# Altium schematic sheet Microcontroleur.SchDoc
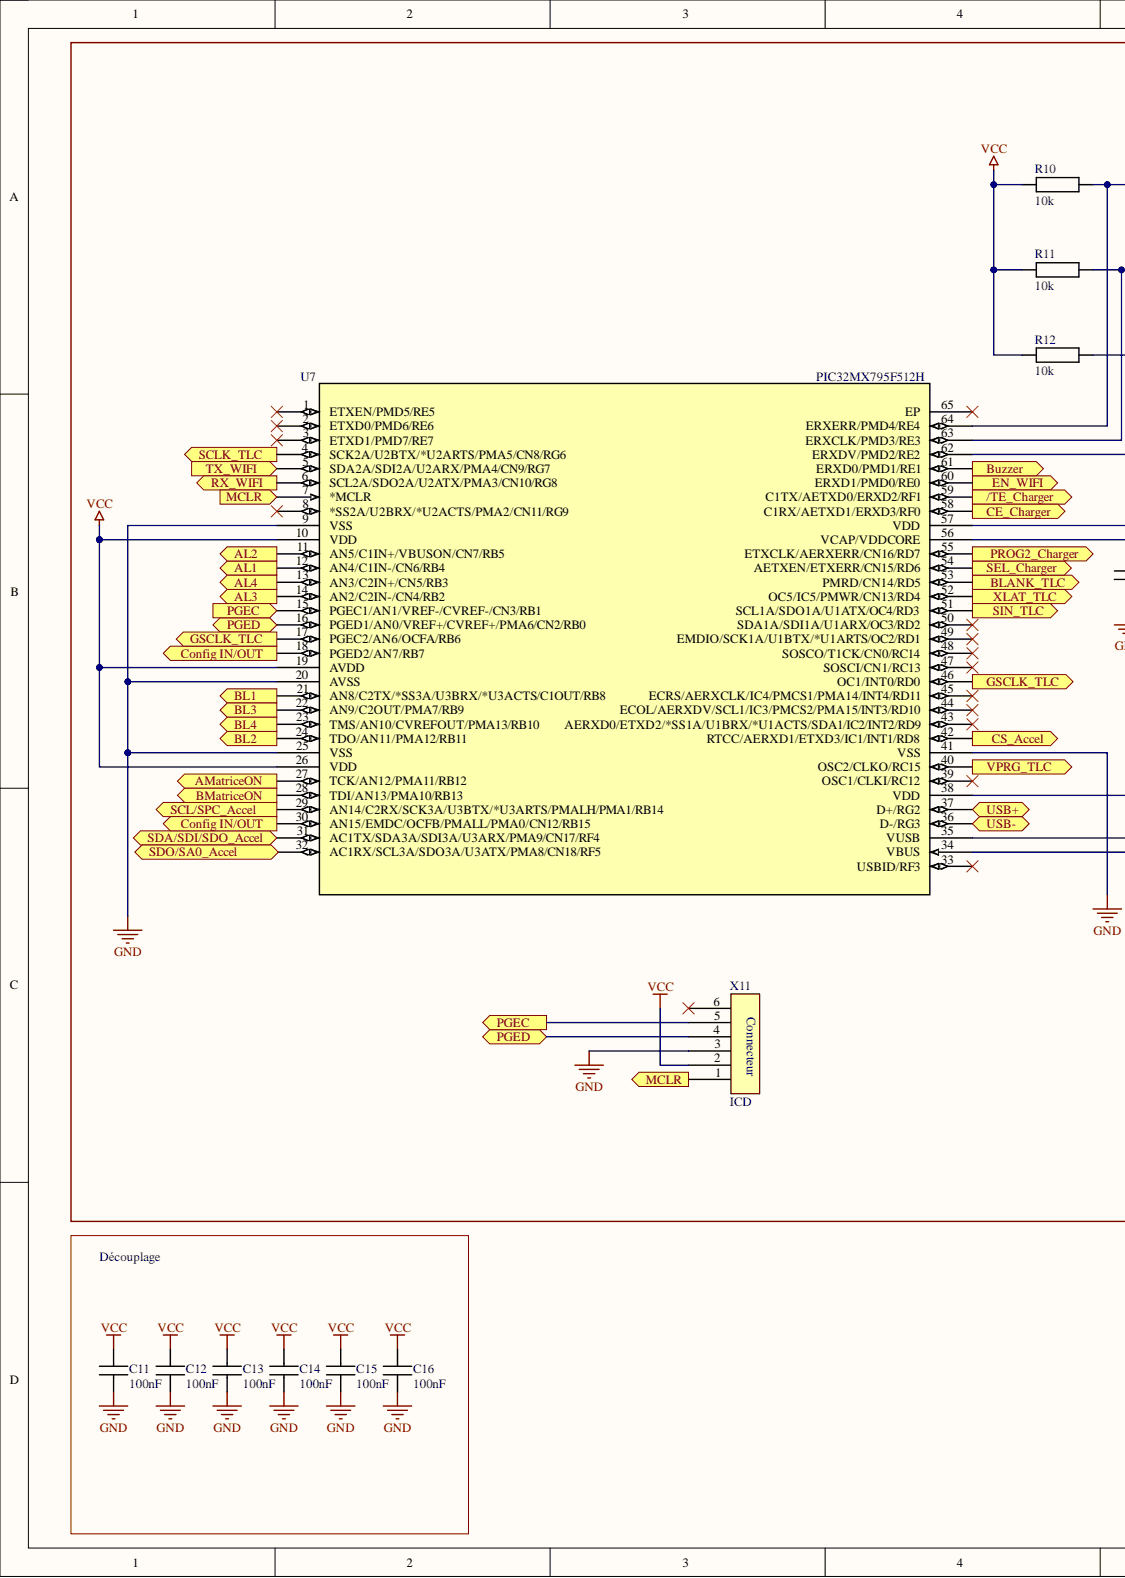
[diagram p0: Microcontroleur.SchDoc, left]
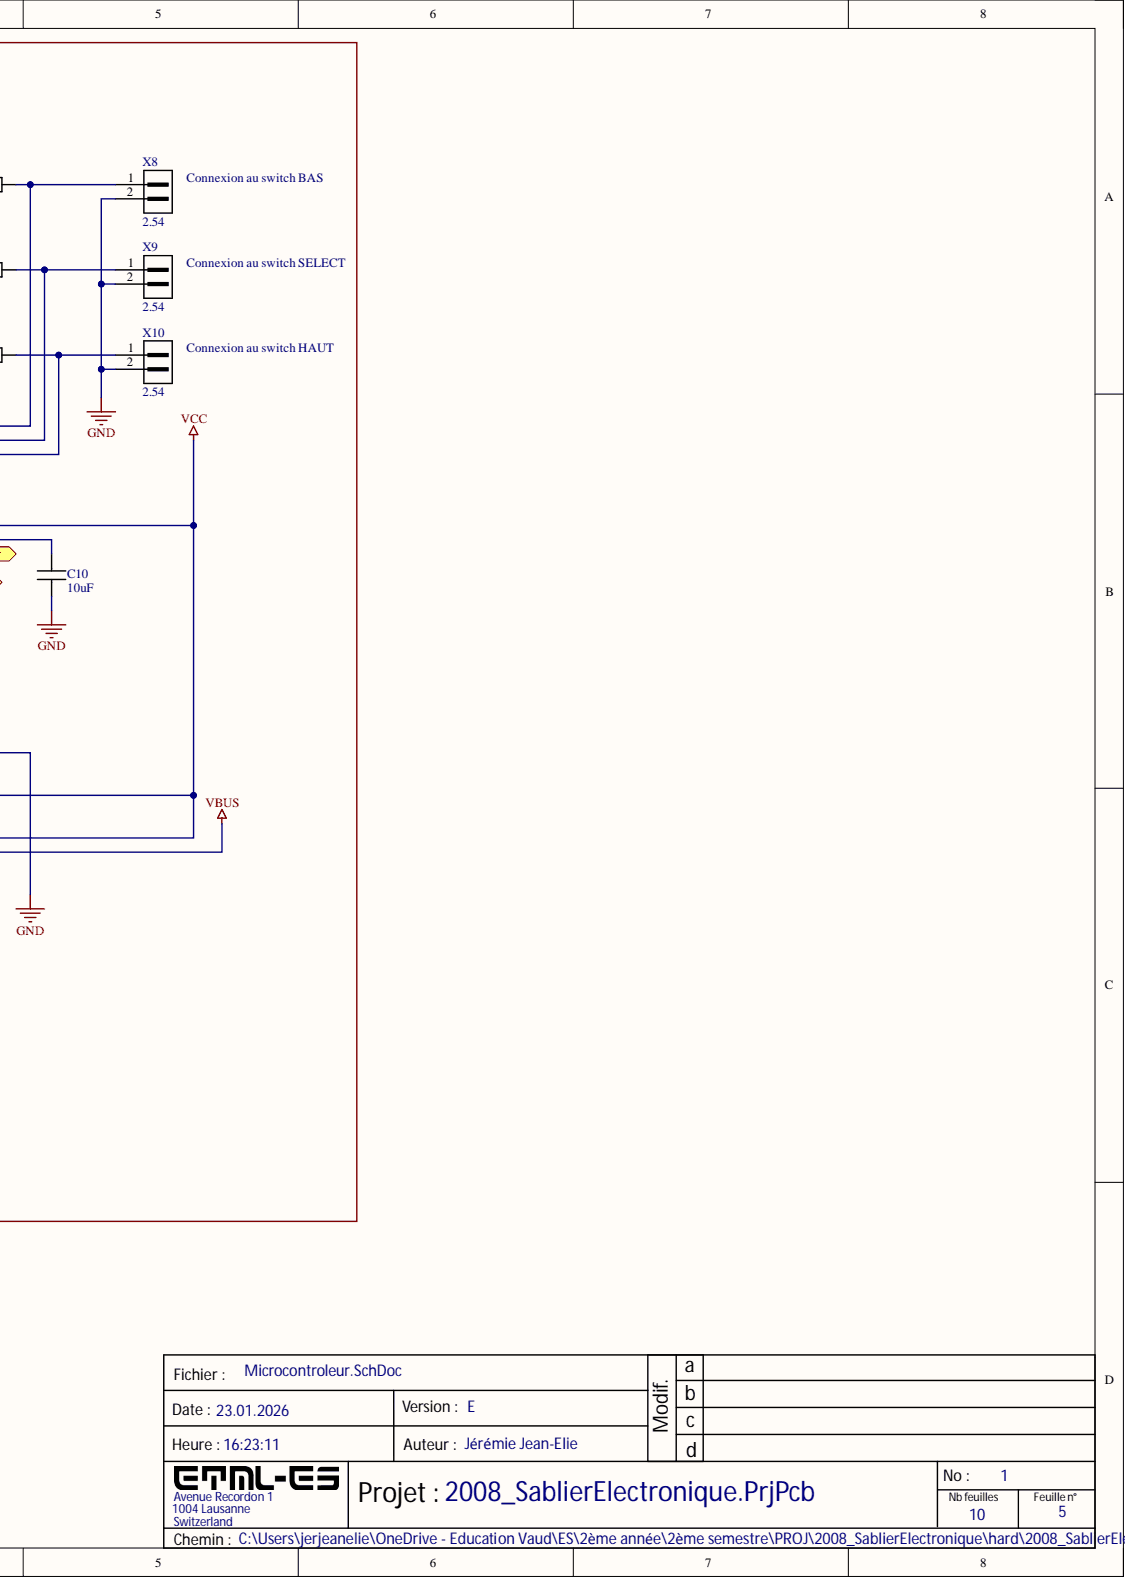
[diagram p1: Microcontroleur.SchDoc, right]

## Parts
C10  10uF  [CAPC1608X08M]
C11  100nF  [CAPC1608X08M]
C12  100nF  [CAPC1608X08M]
C13  100nF  [CAPC1608X08M]
C14  100nF  [CAPC1608X08M]
C15  100nF  [CAPC1608X08M]
C16  100nF  [CAPC1608X08M]
R10  10k  [RESC1608X06M]
R11  10k  [RESC1608X06M]
R12  10k  [RESC1608X06M]
U7  PIC32MX795F512H
X8  2.54  [PIN-1x02-2.54]
X9  2.54  [PIN-1x02-2.54]
X10  2.54  [PIN-1x02-2.54]
X11  ICD  [CON6]

## Ports
/TE_Charger, AL1, AL2, AL3, AL4, AMatriceON, BL1, BL2, BL3, BL4, BLANK_TLC, BMatriceON, Buzzer, CE_Charger, Config IN/OUT, CS_Accel, EN_WIFI, GSCLK_TLC, MCLR, PGEC, PGED, PROG2_Charger, RX_WIFI, SCL/SPC_Accel, SCLK_TLC, SDA/SDI/SDO_Accel, SDO/SA0_Accel, SEL_Charger, SIN_TLC, TX_WIFI, USB+, USB-, VPRG_TLC, XLAT_TLC

## Nets
GND: C10.1 C11.1 C12.1 C13.1 C14.1 C15.1 C16.1 U7.9(VSS) U7.20(AVSS) U7.25(VSS) U7.41(VSS) X8.2 X9.2 X10.2 X11.3
VBUS: U7.34(VBUS)
VCC: C11.2 C12.2 C13.2 C14.2 C15.2 C16.2 R10.2 R11.2 R12.2 U7.10(VDD) U7.19(AVDD) U7.26(VDD) U7.35(VUSB) U7.38(VDD) U7.57(VDD) X11.2
/TE_Charger: U7.59(C1TX/AETXD0/ERXD2/RF1) ; port /TE_Charger
AL1: U7.12(AN4/C1IN-/CN6/RB4) ; port AL1
AL2: U7.11(AN5/C1IN+/VBUSON/CN7/RB5) ; port AL2
AL3: U7.14(AN2/C2IN-/CN4/RB2) ; port AL3
AL4: U7.13(AN3/C2IN+/CN5/RB3) ; port AL4
AMatriceON: U7.27(TCK/AN12/PMA11/RB12) ; port AMatriceON
BL1: U7.21(AN8/C2TX/*SS3A/U3BRX/*U3ACTS/C1OUT/RB8) ; port BL1
BL2: U7.24(TDO/AN11/PMA12/RB11) ; port BL2
BL3: U7.22(AN9/C2OUT/PMA7/RB9) ; port BL3
BL4: U7.23(TMS/AN10/CVREFOUT/PMA13/RB10) ; port BL4
BLANK_TLC: U7.53(PMRD/CN14/RD5) ; port BLANK_TLC
BMatriceON: U7.28(TDI/AN13/PMA10/RB13) ; port BMatriceON
Buzzer: U7.61(ERXD0/PMD1/RE1) ; port Buzzer
CE_Charger: U7.58(C1RX/AETXD1/ERXD3/RF0) ; port CE_Charger
Config IN/OUT: U7.18(PGED2/AN7/RB7) U7.30(AN15/EMDC/OCFB/PMALL/PMA0/CN12/RB15) ; port Config IN/OUT
CS_Accel: U7.42(RTCC/AERXD1/ETXD3/IC1/INT1/RD8) ; port CS_Accel
EN_WIFI: U7.60(ERXD1/PMD0/RE0) ; port EN_WIFI
GSCLK_TLC: U7.17(PGEC2/AN6/OCFA/RB6) U7.46(OC1/INT0/RD0) ; port GSCLK_TLC
MCLR: U7.7(*MCLR) X11.1 ; port MCLR
PGEC: U7.15(PGEC1/AN1/VREF-/CVREF-/CN3/RB1) X11.5 ; port PGEC
PGED: U7.16(PGED1/AN0/VREF+/CVREF+/PMA6/CN2/RB0) X11.4 ; port PGED
PROG2_Charger: U7.55(ETXCLK/AERXERR/CN16/RD7) ; port PROG2_Charger
RX_WIFI: U7.6(SCL2A/SDO2A/U2ATX/PMA3/CN10/RG8) ; port RX_WIFI
SCL/SPC_Accel: U7.29(AN14/C2RX/SCK3A/U3BTX/*U3ARTS/PMALH/PMA1/RB14) ; port SCL/SPC_Accel
SCLK_TLC: U7.4(SCK2A/U2BTX/*U2ARTS/PMA5/CN8/RG6) ; port SCLK_TLC
SDA/SDI/SDO_Accel: U7.31(AC1TX/SDA3A/SDI3A/U3ARX/PMA9/CN17/RF4) ; port SDA/SDI/SDO_Accel
SEL_Charger: U7.54(AETXEN/ETXERR/CN15/RD6) ; port SEL_Charger
SIN_TLC: U7.51(SCL1A/SDO1A/U1ATX/OC4/RD3) ; port SIN_TLC
TX_WIFI: U7.5(SDA2A/SDI2A/U2ARX/PMA4/CN9/RG7) ; port TX_WIFI
VPRG_TLC: U7.40(OSC2/CLKO/RC15) ; port VPRG_TLC
XLAT_TLC: U7.52(OC5/IC5/PMWR/CN13/RD4) ; port XLAT_TLC
NetC10_2: C10.2 U7.56(VCAP/VDDCORE)
NetR10_1: R10.1 U7.64(ERXERR/PMD4/RE4) X8.1
NetR11_1: R11.1 U7.63(ERXCLK/PMD3/RE3) X9.1
NetR12_1: R12.1 U7.62(ERXDV/PMD2/RE2) X10.1
NetU7_36: U7.36(D-/RG3)
NetU7_37: U7.37(D+/RG2)
N00001: ; port SDO/SA0_Accel

Not connected: U7 1(ETXEN/PMD5/RE5) 2(ETXD0/PMD6/RE6) 3(ETXD1/PMD7/RE7) 8(*SS2A/U2BRX/*U2ACTS/PMA2/CN11/RG9) 32(AC1RX/SCL3A/SDO3A/U3ATX/PMA8/CN18/RF5) 33(USBID/RF3) 39(OSC1/CLKI/RC12) 43(AERXD0/ETXD2/*SS1A/U1BRX/*U1ACTS/SDA1/IC2/INT2/RD9) 44(ECOL/AERXDV/SCL1/IC3/PMCS2/PMA15/INT3/RD10) 45(ECRS/AERXCLK/IC4/PMCS1/PMA14/INT4/RD11) 47(SOSCI/CN1/RC13) 48(SOSCO/T1CK/CN0/RC14) 49(EMDIO/SCK1A/U1BTX/*U1ARTS/OC2/RD1) 50(SDA1A/SDI1A/U1ARX/OC3/RD2) 65(EP); X11 6

## Library data of the parts
C10 = CMP-065-000003-2: CAPC 10uF X5R 10V 20% 1608 (0603 INCH); Manufacturer: TDK; Manufacturer Number: C1608X5R1A106M080AC; IsStocked: 1; PN: PN-131657; TemperatureRange: -55°C to +85°C; Value: 10µF; Category: Passives; Component Kind: Standard; Dielectric: X5R; Library Name: ETML-ES; SubCategory: Condensateurs ceramique; Supplier 1: ETML; Supplier Part Number 1: FAB.0603_C 10uF; Supplier Price 1: 0.0944; Tolerance: ±20%; Voltage: 10V
C11-C16 = CMP-065-000000-2: CAPC 100nF X7R 50V 10% 1608 (0603 INCH); Manufacturer: TDK; Manufacturer Number: CGA3E2X7R1H104K080AA; Current: NULL; IsStocked: 1; PN: PN-338083; TemperatureRange: -55°C to +125°C; Category: Passives; Component Kind: Standard; Dielectric: X7R; Library Name: ETML-ES; Power: NULL; SubCategory: Condensateurs ceramique; Supplier 1: ETML; Supplier Part Number 1: FAB.0603_C 100n; Supplier Price 1: 0.0100; TemperatureCoeff: NULL; Tolerance: ±10%; Value: 100nF; Voltage: 50V
R10-R12 = CMP-066-000124-2: RES 10kohm  ±1% 0.1W  1608 (0603 INCH); Manufacturer: Yageo; Manufacturer Number: RC0603FR-0710KL; IsStocked: 1; PN: PN-896786; TemperatureCoeff: ±100ppm/°C; TemperatureRange: -55°C to 155°C; Voltage: 75V; Category: Passives; Component Kind: Standard; Library Name: ETML-ES; Power: 0.1W; SubCategory: Resistances couche epaisse; Supplier 1: ETML; Supplier Part Number 1: FAB.0603_R 10k; Supplier Price 1: 0.0200; Tolerance: ±1%; Value: 10k
X8-X10 = CMP-055-000006-1: PIN HEADER 2.54 1x02; Manufacturer: Würth Electronik; Manufacturer Number: 61300211121; Current: 3A; IsStocked: True; PN: PN-573903; TemperatureRange: -40°C to +105°C; Voltage: 250V; Category: Connectors; Component Kind: Standard; Library Name: ETML-ES; SubCategory: Pin Header; Supplier 1: ETML; Supplier Part Number 1: MAG.283.897; Supplier Price 1: 0.04; Value: 2.54
X11 = Header 6 pins: Component Kind: Standard; Library Name: 2008_SablierElectronique_SCHLIB.SchLib
U7 = PIC32MX795F512H: Component Kind: Standard; Library Name: Schlib1.SchLib
